# Altium schematic sheet p03_MCU_PWR.SchDoc
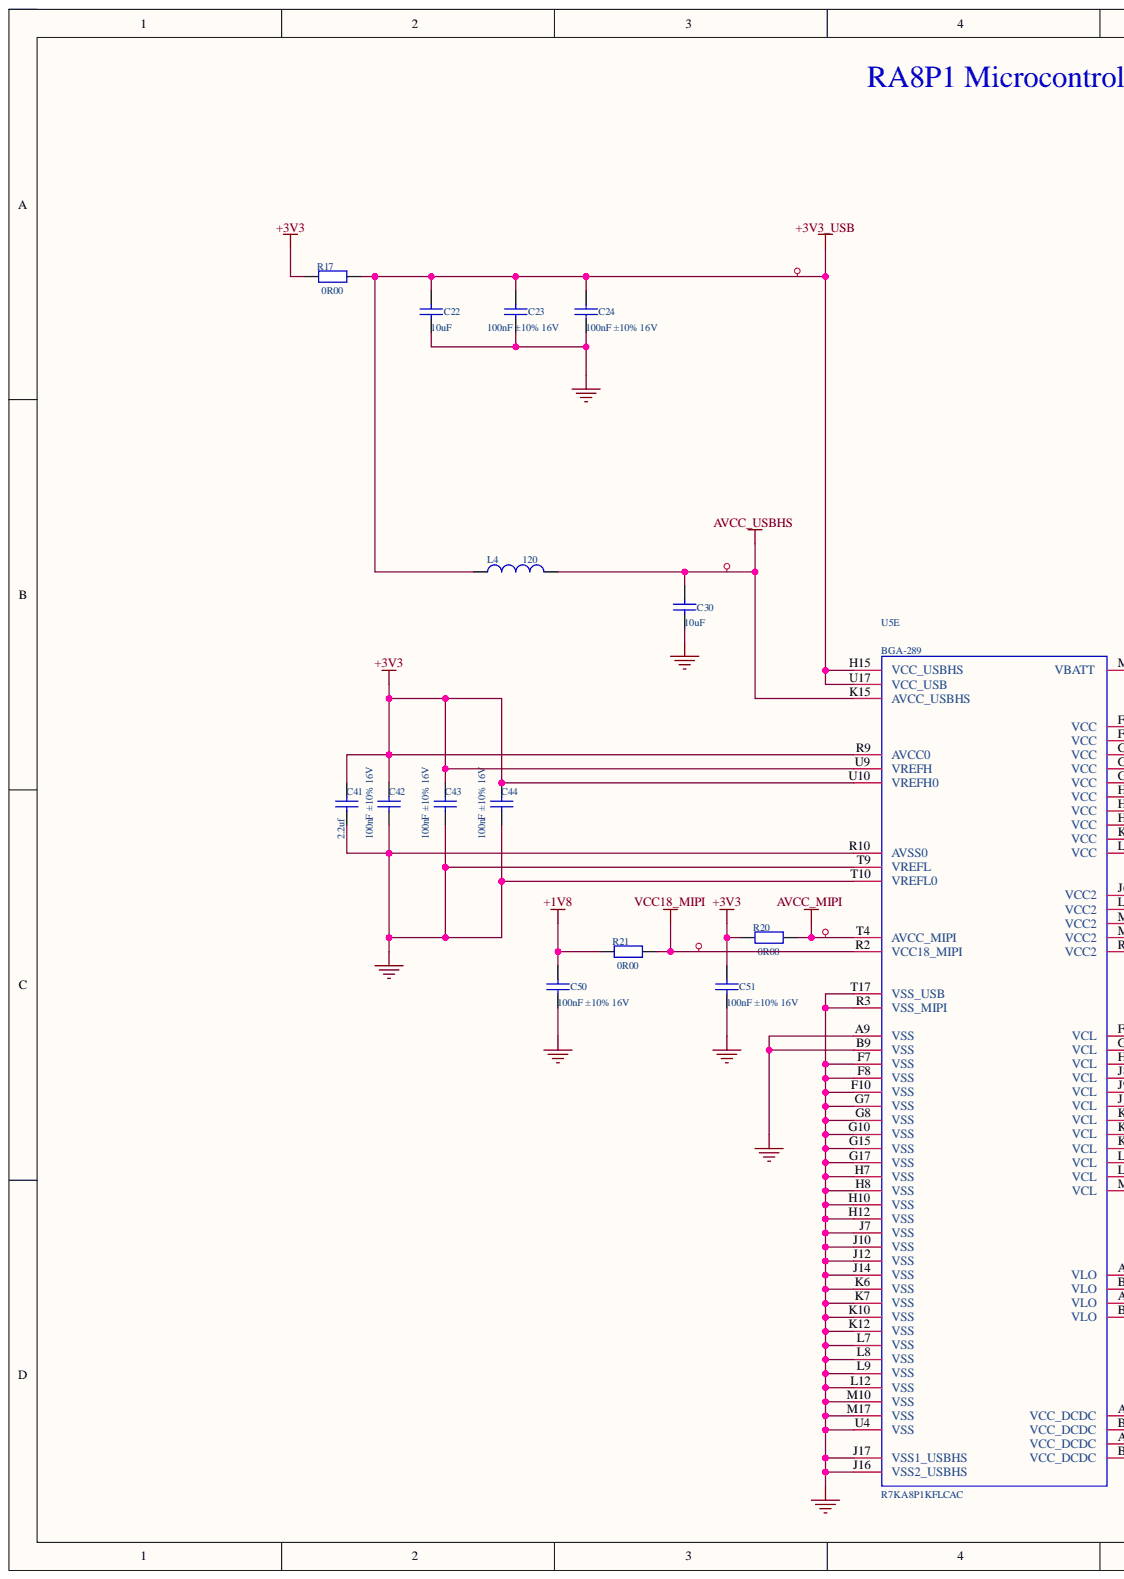
[diagram p0: p03_MCU_PWR.SchDoc, left]
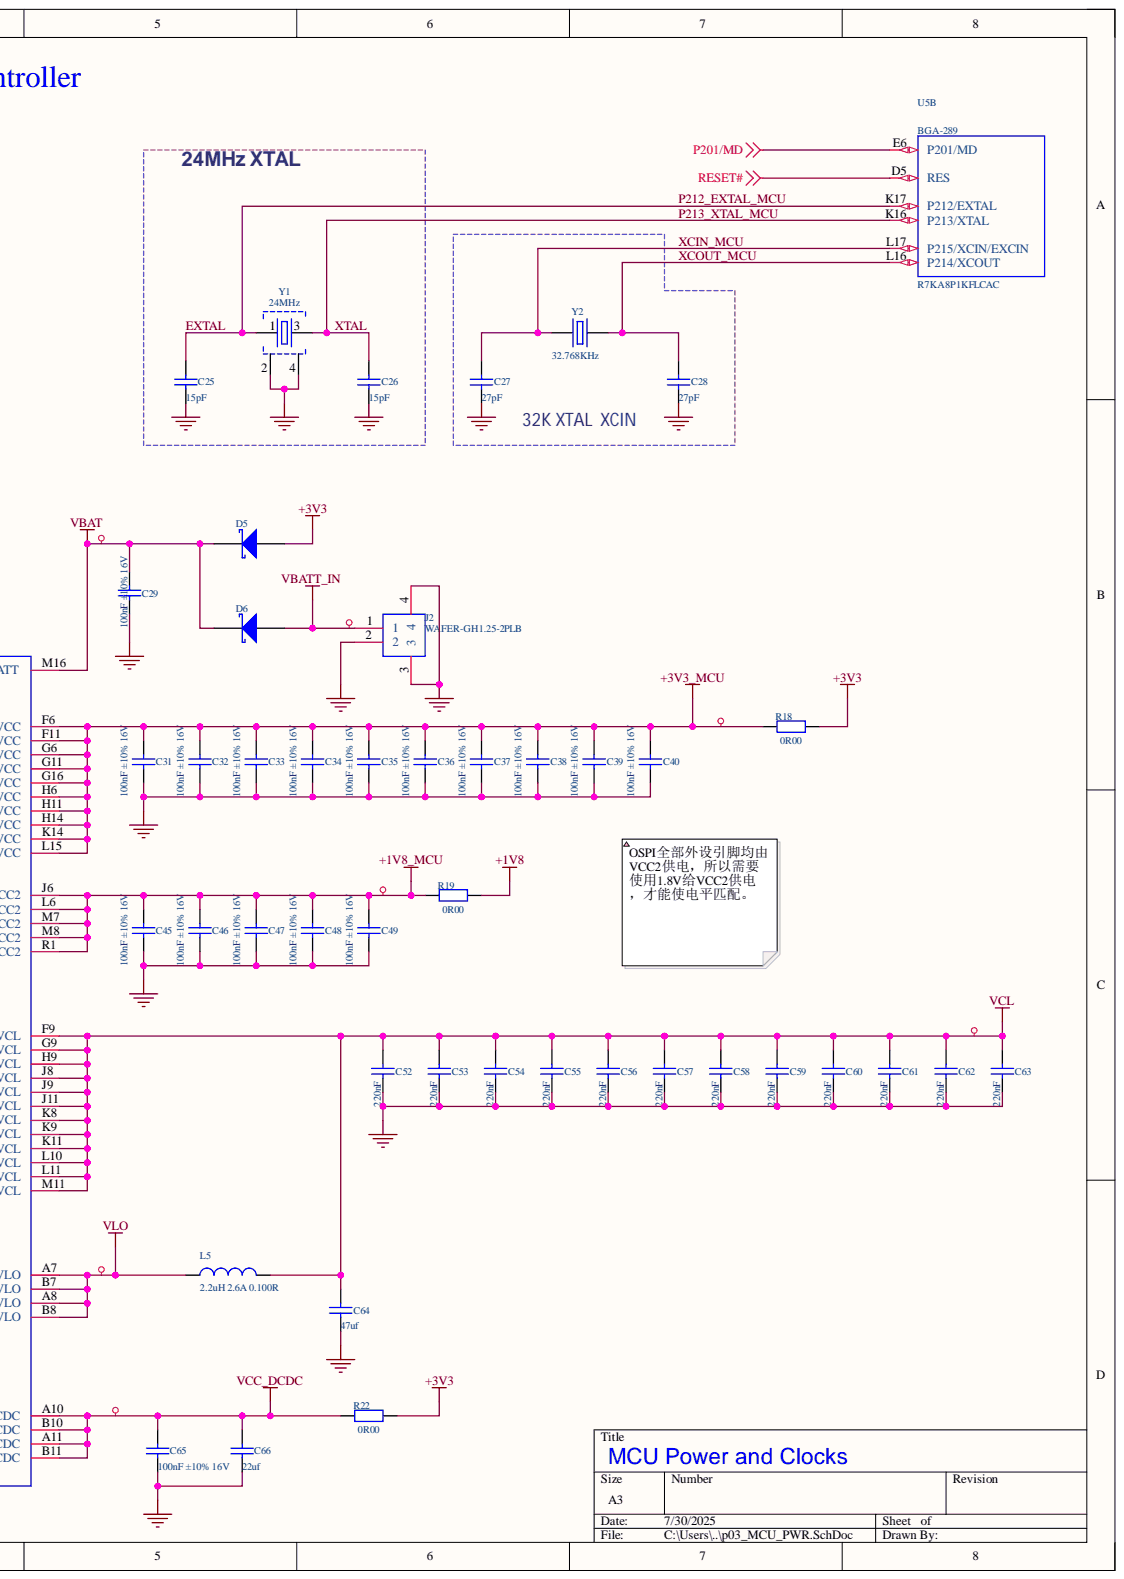
[diagram p1: p03_MCU_PWR.SchDoc, right]

## Parts
C22  10uF  [C0603]
C23  100nF ±10% 16V  Capacitance: 100nF  Voltage: 16V  [C0201]
C24  100nF ±10% 16V  Capacitance: 100nF  Voltage: 16V  [C0201]
C25  15pF  [C0201]
C26  15pF  [C0201]
C27  27pF  [C0201]
C28  27pF  [C0201]
C29  100nF ±10% 16V  Capacitance: 100nF  Voltage: 16V  [C0201]
C30  10uF  [C0603]
C31  100nF ±10% 16V  Capacitance: 100nF  Voltage: 16V  [C0201]
C32  100nF ±10% 16V  Capacitance: 100nF  Voltage: 16V  [C0201]
C33  100nF ±10% 16V  Capacitance: 100nF  Voltage: 16V  [C0201]
C34  100nF ±10% 16V  Capacitance: 100nF  Voltage: 16V  [C0201]
C35  100nF ±10% 16V  Capacitance: 100nF  Voltage: 16V  [C0201]
C36  100nF ±10% 16V  Capacitance: 100nF  Voltage: 16V  [C0201]
C37  100nF ±10% 16V  Capacitance: 100nF  Voltage: 16V  [C0201]
C38  100nF ±10% 16V  Capacitance: 100nF  Voltage: 16V  [C0201]
C39  100nF ±10% 16V  Capacitance: 100nF  Voltage: 16V  [C0201]
C40  100nF ±10% 16V  Capacitance: 100nF  Voltage: 16V  [C0201]
C41  2.2uf  [C0402]
C42  100nF ±10% 16V  Capacitance: 100nF  Voltage: 16V  [C0201]
C43  100nF ±10% 16V  Capacitance: 100nF  Voltage: 16V  [C0201]
C44  100nF ±10% 16V  Capacitance: 100nF  Voltage: 16V  [C0201]
C45  100nF ±10% 16V  Capacitance: 100nF  Voltage: 16V  [C0201]
C46  100nF ±10% 16V  Capacitance: 100nF  Voltage: 16V  [C0201]
C47  100nF ±10% 16V  Capacitance: 100nF  Voltage: 16V  [C0201]
C48  100nF ±10% 16V  Capacitance: 100nF  Voltage: 16V  [C0201]
C49  100nF ±10% 16V  Capacitance: 100nF  Voltage: 16V  [C0201]
C50  100nF ±10% 16V  Capacitance: 100nF  [C0201]
C51  100nF ±10% 16V  Capacitance: 100nF  [C0201]
C52  220nF  [C0201]
C53  220nF  [C0201]
C54  220nF  [C0201]
C55  220nF  [C0201]
C56  220nF  [C0201]
C57  220nF  [C0201]
C58  220nF  [C0201]
C59  220nF  [C0201]
C60  220nF  [C0201]
C61  220nF  [C0201]
C62  220nF  [C0201]
C63  220nF  [C0201]
C64  47uf  [C0805]
C65  100nF ±10% 16V  Capacitance: 100nF  [C0201]
C66  22uf  [C0805]
D5  B5819WS  [SOD-323_L1.7-W1.3-LS2.5-RD-1]  (value not drawn)
D6  B5819WS  [SOD-323_L1.7-W1.3-LS2.5-RD-1]  (value not drawn)
J2  WAFER-GH1.25-2PLB  [CONN-TH_2P-P1.25_XUNPU_WAFER-GH1.25-2PLB]
L4  IND-100020-01  [FB_0402]  (value not drawn)
L5  value 2.2uH 2.6A 0.100R  [IND_TDK_VLS252010HBX-1]  (hidden comment: Inductor)
R17  0R00  [R0603]
R18  0R00  [R0603]
R19  0R00  [R0603]
R20  0R00  [R0603]
R21  0R00  [R0603]
R22  0R00  [R0603]
U5  R7KA8P1KFLCAC  Package: BGA-289  [RENESAS_P289F1-65-EAT_BGA-289]  (parts B,E)
Y1  value 24MHz  [SMD 2016-4P]  (hidden comment: XL7EL89CMI-111YLC-24M)
Y2  Frequency: 32.768KHz  [SMD 2012-2P]  (hidden comment: XKHEL89CGI-112YLC-32.768K)

## Nets
+1V8: C50.2 R19.2 R21.1
+1V8_MCU: C45.2 C46.2 C47.2 C48.2 C49.2 R19.1 U5.J6(VCC2) U5.L6(VCC2) U5.M7(VCC2) U5.M8(VCC2) U5.R1(VCC2)
+3V3: C41.2 C42.2 C43.2 C44.2 C51.2 D5.2 R17.1 R18.2 R20.1 R22.2 U5.R9(AVCC0) U5.U9(VREFH) U5.U10(VREFH0)
+3V3_MCU: C31.2 C32.2 C33.2 C34.2 C35.2 C36.2 C37.2 C38.2 C39.2 C40.2 R18.1 U5.F6(VCC) U5.F11(VCC) U5.G6(VCC) U5.G11(VCC) U5.G16(VCC) U5.H6(VCC) U5.H11(VCC) U5.H14(VCC) U5.K14(VCC) U5.L15(VCC)
+3V3_USB: C22.2 C23.2 C24.2 L4.1 R17.2 U5.H15(VCC_USBHS) U5.U17(VCC_USB)
AVCC_MIPI: R20.2 U5.T4(AVCC_MIPI)
AVCC_USBHS: C30.2 L4.2 U5.K15(AVCC_USBHS)
GND: C22.1 C23.1 C24.1 C25.1 C26.1 C27.1 C28.1 C29.1 C30.1 C31.1 C32.1 C33.1 C34.1 C35.1 C36.1 C37.1 C38.1 C39.1 C40.1 C41.1 C42.1 C43.1 C44.1 C45.1 C46.1 C47.1 C48.1 C49.1 C50.1 C51.1 C52.1 C53.1 C54.1 C55.1 C56.1 C57.1 C58.1 C59.1 C60.1 C61.1 C62.1 C63.1 C64.1 C65.1 C66.1 J2.2 J2.3 J2.4 U5.A9(VSS) U5.B9(VSS) U5.F7(VSS) U5.F8(VSS) U5.F10(VSS) U5.G7(VSS) U5.G8(VSS) U5.G10(VSS) U5.G15(VSS) U5.G17(VSS) U5.H7(VSS) U5.H8(VSS) U5.H10(VSS) U5.H12(VSS) U5.J7(VSS) U5.J10(VSS) U5.J12(VSS) U5.J14(VSS) U5.J16(VSS2_USBHS) U5.J17(VSS1_USBHS) U5.K6(VSS) U5.K7(VSS) U5.K10(VSS) U5.K12(VSS) U5.L7(VSS) U5.L8(VSS) U5.L9(VSS) U5.L12(VSS) U5.M10(VSS) U5.M17(VSS) U5.R3(VSS_MIPI) U5.R10(AVSS0) U5.T9(VREFL) U5.T10(VREFL0) U5.T17(VSS_USB) U5.U4(VSS) Y1.2 Y1.4
P201/MD: U5.E6(P201/MD)
RESET#: U5.D5(RES)
VBAT: C29.2 D5.1 D6.1 U5.M16(VBATT)
VBATT_IN: D6.2 J2.1
VCC18_MIPI: R21.2 U5.R2(VCC18_MIPI)
VCC_DCDC: C65.2 C66.2 R22.1 U5.A10(VCC_DCDC) U5.A11(VCC_DCDC) U5.B10(VCC_DCDC) U5.B11(VCC_DCDC)
VCL: C52.2 C53.2 C54.2 C55.2 C56.2 C57.2 C58.2 C59.2 C60.2 C61.2 C62.2 C63.2 C64.2 L5.2 U5.F9(VCL) U5.G9(VCL) U5.H9(VCL) U5.J8(VCL) U5.J9(VCL) U5.J11(VCL) U5.K8(VCL) U5.K9(VCL) U5.K11(VCL) U5.L10(VCL) U5.L11(VCL) U5.M11(VCL)
VLO: L5.1 U5.A7(VLO) U5.A8(VLO) U5.B7(VLO) U5.B8(VLO)
EXTAL: C25.2 U5.K17(P212/EXTAL) Y1.1
P213_XTAL_MCU: C26.2 U5.K16(P213/XTAL) Y1.3
XCIN_MCU: C27.2 U5.L17(P215/XCIN/EXCIN) Y2.1
XCOUT_MCU: C28.2 U5.L16(P214/XCOUT) Y2.2

## Library data of the parts
C22 C30 = CAP-000073-01: GRM188R61E106KA73D，0603，10uF ±20% 10V; Manufacturer: muRata; Manufacturer Part Number 1: CL05A106MQ5NUNC; Dialectric: X5R; Notes: ANY EQUIVALENT; Capacitance: 10uF; ClassName: MCU; Component Kind: Standard; Library Name: Capacitors.IntLib; Manufacturer 1: muRata; Mfg: muRata; Mfg P/N: CL05A106MQ5NUNC; Mfg Part Number: CL05A106MQ5NUNC; Package: 0402; PN: CL05A106MQ5NUNC; Supplier 1: Digi-Key; Supplier Part Number 1: 1276-1451-1-ND; Tolerance: 20%; Voltage: 10V
  C22: ComponentLink1Description: New link; ComponentLink1URL: https://item.szlcsc.com/78127.html?fromZone=s_s__%252210UF%25200402%2522&spm=sc.gb.xh4.zy.n___sc.gb.hd.ss&lcsc_vid=RwBfVQZSEwJZV1NUEVEPX1cHQQNZXgUCFFhXBVwEFFcxVlNST1VeVFdVRlRXVDsOAxUeFF5JWBYZEEoVDQ0NFAdIFA4DSA%3D%3D
C23 C24 C29 C31-C40 C42-C51 C65 = CAP-000030-01: 0201,100nF ±10% 16V，X7R; Legacy Part Number: 151-10004022; Manufacturer: muRata; Manufacturer Part Number 1: GRM033Z71C104KE14D; Dialectric: X7R; Notes: ANY EQUIVALENT; ClassName: MCU; Component Kind: Standard; Library Name: Capacitors.IntLib; Manufacturer 1: muRata; Manufacturer 3: AVX; Manufacturer Part Number 3: 0402YC104KAT2A; Mfg: muRata; Mfg P/N: GRM033Z71C104KE14D; Mfg Part Number: GRM033Z71C104KE14D; PN: GRM033Z71C104KE14D; Supplier 1: Arrow Electronics; Supplier 2: Digi-Key; Supplier 3: Newark; Supplier 4: Mouser; Supplier Part Number 1: CC0402KRX7R8BB104; Supplier Part Number 2: 311-2077-1-ND; Supplier Part Number 3: 40AJ6370; Supplier Part Number 4: 603-CC402KRX7R8BB104; Tolerance: 10%
  C23 C24 C43 C44: Package: 0402
  C29 C31-C40 C45-C49: Package: 0201
  C42: ComponentLink1Description: New link; ComponentLink1URL: https://item.szlcsc.com/698285.html?fromZone=l_c__%2522catalog%2522&spm=sc.cid.xh3.zy.n___sc.gb.xh1.zy.l&lcsc_vid=FgdZAQZURgVfVlRQQQNbBAcFQVZYX1NeQ1BYX10ARFkxVlNSQ1FZUVReQVdaUjsOAxUeFF5JWAgaAglIBBsCBBcFWQIBCks%3D; Package: 0402
  C50 C51 C65: Package: 0201; Voltage: 16V
C25 C26 = CAP-000092-01: Ceramic Chip Capacitor 0402 15pF 25V; Manufacturer: TDK; Manufacturer Part Number 1: C0603C0G1E150J030BA; Dialectric: C0G; Notes: ANY EQUIVALENT; Tolerance: 5%; Capacitance: 15pF; ClassName: MCU; Component Kind: Standard; Library Name: Capacitors.IntLib; Manufacturer 1: TDK; Mfg: TDK; Mfg Part Number: C0603C0G1E150J030BA; Package: 0201; PN: C0603C0G1E150J030BA; Voltage: 25V
C27 C28 = CAP-100128-01: Ceramic Chip Capacitor 0402 27pF 50V，GRM0335C1H270JA01D; Legacy Part Number: 151-10007051; Manufacturer: Yageo; Manufacturer Part Number 1: CC0402JRNPO9BN270; Dialectric: C0G/NPO; Notes: ANY EQUIVALENT; Tolerance: 5%; Voltage: 50V; Capacitance: 27pF; ClassName: MCU; Component Kind: Standard; Library Name: Capacitors.IntLib; Manufacturer 1: Yageo; Mfg: Yageo; Mfg P/N: CC0402JRNPO9BN270; Mfg Part Number: CC0402JRNPO9BN270; Package: 0402; PN: CC0402JRNPO9BN270; Supplier 1: Future Electronics; Supplier 2: Digi-Key; Supplier 3: Mouser; Supplier 4: Farnell; Supplier Part Number 1: 9741513; Supplier Part Number 2: 311-1019-1-ND; Supplier Part Number 3: 603-CC402JRNPO9BN270; Supplier Part Number 4: 3369115
C41 = CAP-000067-01: 0402，2.2uF ±10% 10V，X7R，GRM033R61A225ME47D; Manufacturer: muRata; Manufacturer Part Number 1: GRM155Z71A225KE01D; Dialectric: X7R; Notes: ANY EQUIVALENT; Voltage: 10V; Capacitance: 2.2uF; ClassName: MCU; Component Kind: Standard; ComponentLink1Description: New link; ComponentLink1URL: https://item.szlcsc.com/3468099.html?fromZone=l_c__%2522catalog%2522&spm=sc.cid.xh2.zy.n___sc.gb.xh1.zy.l&lcsc_vid=FgdZAQZURgVfVlRQQQNbBAcFQVZYX1NeQ1BYX10ARFkxVlNSQ1FZUVReQVdaUjsOAxUeFF5JWAgaAglIBBsCBBcFWQIBCks%3D; Library Name: Capacitors.IntLib; Manufacturer 1: muRata; Manufacturer 2: Samsung; Manufacturer Part Number 2: CL05A225MP5NNNC; Mfg: muRata; Mfg P/N: GRM155Z71A225KE01D; Mfg Part Number: GRM155Z71A225KE01D; Package: 0402; Tolerance: 10%
C52-C63 = CAP-100140-01: 0201,220nF ±10% 16V,X5R; Manufacturer: muRata; Manufacturer Part Number 1: C1005X7R1C224K050BC; Notes: ANY EQUIVALENT; Capacitance: 220nF; ClassName: MCU; Component Kind: Standard; Dialectric: X5R; Library Name: Capacitors.IntLib; Manufacturer 1: muRata; Mfg: muRata; Mfg Part Number: C1005X7R1C224K050BC; Package: 0201; PN: C1005X7R1C224K050BC; Supplier 1: Arrow Electronics; Supplier 2: Newark; Supplier 3: Mouser; Supplier 4: Digi-Key; Supplier Part Number 1: C1005X7R1C224K050BC; Supplier Part Number 2: 05X9841; Supplier Part Number 3: 810-C1005X7R1C224K; Supplier Part Number 4: 445-5936-1-ND; Tolerance: 10%; Voltage: 16V
  C52: ComponentLink1Description: New link; ComponentLink1URL: https://item.szlcsc.com/172855.html?fromZone=l_c__%2522catalog%2522&spm=sc.cid.xh1.zy.n___sc.gb.xh1.zy.l&lcsc_vid=FgdZAQZURgVfVlRQQQNbBAcFQVZYX1NeQ1BYX10ARFkxVlNSQ1FZUVReQVdaUjsOAxUeFF5JWAgaAglIBBsCBBcFWQIBCks%3D
C64 = CAP-100142-01: 0805,47uF ±20% 6.3V,X5R,GRM21BR60J476ME15L; Manufacturer: Murata; Notes: MUST USE SPECIFIC; Capacitance: 47uF; ClassName: MCU; Component Kind: Standard; ComponentLink1Description: New link; ComponentLink1URL: https://item.szlcsc.com/78199.html?fromZone=s_s__%252247uf%25200805%2522&spm=sc.gbn.xh6.zy.n&lcsc_vid=RwBfVQZSEwJZV1NUEVEPX1cHQQNZXgUCFFhXBVwEFFcxVlNSTlRfX1xUTlJbUjsOAxUeFF5JWBIBSRccGwIdBEoFGAxBAAgJFQACSQwSGg0%3D; Dialectric: X5R; Library Name: Capacitors.IntLib; Manufacturer 1: Murata; Manufacturer Part Number 1: GRM21BR60J476ME15L; Mfg: Murata; Mfg P/N: GRM21BR60J476ME15L; Mfg Part Number: GRM21BR60J476ME15L; Package: 0603; PN: GRM21BR60J476ME15L; Supplier 1: Arrow Electronics; Supplier 2: Newark; Supplier 3: Mouser; Supplier 4: Digi-Key; Supplier Part Number 1: GRM32ER70J476KE20L; Supplier Part Number 2: 13T1230; Supplier Part Number 3: 81-GRM32ER70J476KE0L; Supplier Part Number 4: 490-5311-1-ND; Tolerance: 20%; Voltage: 6.3V
C66 = CAP-100141-01: GRM21BZ71A226ME15L,0805,22uF ±20% 10V，X7R; Manufacturer: Murata; Dialectric: X7R; Notes: ANY EQUIVALENT; Tolerance: 10%; Voltage: 6.3V; Capacitance: 22uF; ClassName: MCU; Component Kind: Standard; ComponentLink1Description: New link; ComponentLink1URL: https://item.szlcsc.com/973892.html?fromZone=s_s__%252222uf%25200805%2522&spm=sc.gbn.xh11.zy.n&lcsc_vid=RwBfVQZSEwJZV1NUEVEPX1cHQQNZXgUCFFhXBVwEFFcxVlNSTlRfX11QT1NaUjsOAxUeFF5JWBIBSRccGwIdBEoFGAxBAAgJFQACSQwSGg0%3D; Library Name: Capacitors.IntLib; Manufacturer 1: Murata; Manufacturer Part Number 1: GRM21BZ71A226ME15L; Mfg: Murata; Mfg P/N: GRM21BZ71A226ME15L; Mfg Part Number: GRM21BZ71A226ME15L; Package: 1206; PN: GRM21BZ71A226ME15L; Supplier 1: Arrow Electronics; Supplier 2: Newark; Supplier 3: Mouser; Supplier 4: Digi-Key; Supplier Part Number 1: GRM31CR70J226KE19L; Supplier Part Number 2: 64AJ4427; Supplier Part Number 3: 81-GRM31CR70J226KE9L; Supplier Part Number 4: 490-6515-1-ND
D5 D6 = B5819WS: Schottky Barrier Diode, 1A 40V, 0.60Vf @ 1A, 1mA max Ir; Manufacturer: Rohm; Manufacturer Part Number 1: RB168MM-40; Ifsm: 40A; Ir_max: 0.55uA; Ir_typ: 0.05uA; Value: RB168MM-40; ClassName: MCU; Component Kind: Standard; Io: 1A; Library Name: ra8p1_v0.2.SCHLIB; Manufacturer 1: Rohm; Mfg: Rohm; Mfg P/N: RB168MM-40; Mfg Part Number: RB168MM-40; PN: RB168MM-40; Supplier 1: Avnet; Supplier 2: Digi-Key; Supplier 3: Future Electronics; Supplier 4: Mouser; Supplier Part Number 1: RB168MM-40TR; Supplier Part Number 2: RB168MM-40CT-ND; Supplier Part Number 3: 9087689; Supplier Part Number 4: 755-RB168MM-40TR; Vr: 40V
J2 = WAFER-GH1.25-2PLB: 立式; Manufacturer: XUNPU(讯普); Add into BOM: yes; Current Rating: 1A; Number of PINs Per Row: 2; Pins Structure: 1x2P; Pitch: 1.25mm; Plastic Material: LCP; Reference Series: GH; Supplementary Features: 辅助焊脚; Voltage Rating: 125V; X-Length of Bottom Edge on Board (Spacing Line): 5.75mm; Y-Width of Bottom Edge on Board: 4.95mm; Z-Height of the Board: 4.2mm; Color: 米色; Component Kind: Standard; Contact Material: 黄铜; Contact Plating: -; Convert to PCB: yes; Datasheet: https://atta.szlcsc.com/upload/public/pdf/source/20220527/44F824AAC8C2B617205E15955FF9C23D.pdf; Device: WAFER-GH1.25-2PLB; Flame Retardant Rating: -; JLCPCB Part Class: Extended Part; LCSC Part Name: 1x2P 间距:1.25mm 立贴 系列:GH; Library Name: ra8p1_v0.1.SCHLIB; Manufacturer Part: WAFER-GH1.25-2PLB; Mounting Type: 立贴; Number of Pins: 2P; Number of Rows: 1; Operating Temperature: -25℃~+85℃; PCB3D: CONN-TH_2P-P1.25_XUNPU_WAFER-GH1.25-2PLB; Row Spacing: -; Supplier: LCSC; Supplier Footprint: SMD,P=1.25mm; Supplier Part: C3029368; Symbol: WAFER-GH1.25-2PLB
L4 = IND-100020-01: Chip Ferrite Bead 0402 120ohm 1.2A 25%; Manufacturer: TDK; Manufacturer Part Number 1: MPZ1005S121CT000; Notes: ANY EQUIVALENT; Resistance: 120; ClassName: MCU; Component Kind: Standard; Current: 1.2A; Library Name: Misc.IntLib; Manufacturer 1: TDK; Mfg: TDK; Mfg Part Number: MPZ1005S121CT000; Package: 0402; PN: MPZ1005S121CT000; Supplier 1: Avnet; Supplier 2: Mouser; Supplier 3: Digi-Key; Supplier Part Number 1: MPZ1005S121CT000; Supplier Part Number 2: 810-MPZ1005S121CT000; Supplier Part Number 3: 445-2981-1-ND; Tolerance: 25%
L5 = MCD-00240: FIXED IND 2.2UH 2.6A 100 mOHM 20% SMD; Manufacturer: TDK; Manufacturer_Part_Number: VLS252010HBX-2R2M-1; Provided_By: SUBCO; Component Kind: Standard; ComponentLink1Description: Datasheet; ComponentLink1URL: http://tunmcdsvn.tun.st.com/Component_Datasheet_Library/Trunk/Inductors/TDK_Inductor_VLS252010HBX-1; ECCN_EU: n/a; ECCN_US: n/a; Internal_Part_Number: MCD-00240; Library Name: ra8p1_v0.2.SCHLIB; Part_Type: Inductor; Status: Released
R17-R22 = RES-000221-01: Chip Resistor Thick Film 1206  0R00 1% 1/4W; Legacy Part Number: 150-00000004; Manufacturer: Yageo; Manufacturer Part Number 1: RC1206JR-070RL; Notes: ANY EQUIVALENT; ClassName: MCU; Component Kind: Standard; Library Name: Resistors.IntLib; Manufacturer 1: Yageo; Mfg: Yageo; Mfg Part Number: RC1206JR-070RL; Package: 1206; PN: RC1206JR-070RL; Power: 1/4W; Resistance: 0R00; Supplier 1: Digi-Key; Supplier 2: Future Electronics; Supplier 3: Arrow Electronics; Supplier 4: Avnet; Supplier 5: Digi-Key; Supplier 6: Mouser; Supplier Part Number 1: P0.0ECT-ND; Supplier Part Number 2: 1414689; Supplier Part Number 3: RC1206JR-070RL; Supplier Part Number 4: RC1206JR-070RL; Supplier Part Number 5: 311-0.0ERCT-ND; Supplier Part Number 6: 603-RC1206JR-070RL; Tolerance: 1%
U5 = ICN-100026-01: Renesas RA8P1 32-bit MCU BGA-289 12x12mm 0.65mm pitch; Architecture: 32bits; Code Flash: 1MB; Max Clock Speed: 1GHz; Notes: MUST USE SPECIFIC ?? FREE ISSUE ??; SRAM: 2MB; ClassName: BGA_MCU; Component Kind: Standard; Library Name: ra8p1_v0.1.SCHLIB; Manufacturer: Renesas; Manufacturer 1: Renesas; Manufacturer Part Number 1: R7KA8P1KFLCAC; Mfg: Renesas; Mfg Part Number: R7KA8P1KFLCAC; PN: R7KA8P1KFLCAC
Y1 = XTL-100003-01: 24MHz ±10ppm 10pF; Legacy Part Number: 170-10007505; Manufacturer: Diodes Inc.; Manufacturer Part Number 1: FL2400022; Comment1: 24MHz 10ppmTol 10ppmStab 10pF 50oESR SMD3.2x2.5x0.8mm; Notes: MUST USE SPECIFIC; Subs Approved: ABM8X-101-24.000MHZ-T; ClassName: MCU; Component Kind: Standard; ComponentLink1Description: New link; ComponentLink1URL: https://item.szlcsc.com/71681.html?fromZone=l_b__%2522brand%2522&spm=sc.bid.xh6.zy.t&lcsc_vid=FANcVFBQFQMNX1cFFAdZVVZXEgdeAgdWFlQNUVAHT1ExVlNSRFhXUVRRT1NYVjsOAxUeFF5JWA0HFBBIBBsCBBcFWQIBCksEBQAAAzsWFgYLSFZeQE8GEwkK; Library Name: Misc.IntLib; Manufacturer 1: Diodes Inc.; Mfg: Diodes Inc.; Mfg P/N: FL2400022; Mfg Part Number: FL2400022; Supplier 1: Digi-Key; Supplier Part Number 1: FL2400022CT-ND
Y2 = XTL-000006-01: 32.768kHz ±20ppm 12.5pF; Manufacturer: Abracon; Manufacturer Part Number 1: ABS07-32.768KHZ-T; Freq Stability: +/- 20ppm; Notes: MUST USE SPECIFIC; ClassName: MCU; Component Kind: Standard; ComponentLink1Description: New link; ComponentLink1URL: https://item.szlcsc.com/8579117.html?fromZone=l_b__%2522brand%2522&spm=sc.bid.xh1.zy.n&lcsc_vid=E1YIU1FRQVIMBVNRRVhcBAYDQwJbUQICQFgLBVMDElIxVlNSQ1lWU1dRQVlWVTsOAxUeFF5JWA0HFBBIBBsCBBcFWQIBCksEBQAAAzsWFgYLSFZeQE8GEwkK; Library Name: Misc.IntLib; Load Capacitance: 12.5pF; Manufacturer 1: Abracon; Mfg Part Number: ABS07-32.768KHZ-T; Supplier 1: Digi-Key; Supplier Part Number 1: 535-9542-1-ND
